# Altium schematic sheet Power Distribution - Steering, Pedal, Center Console, and Fan.SchDoc
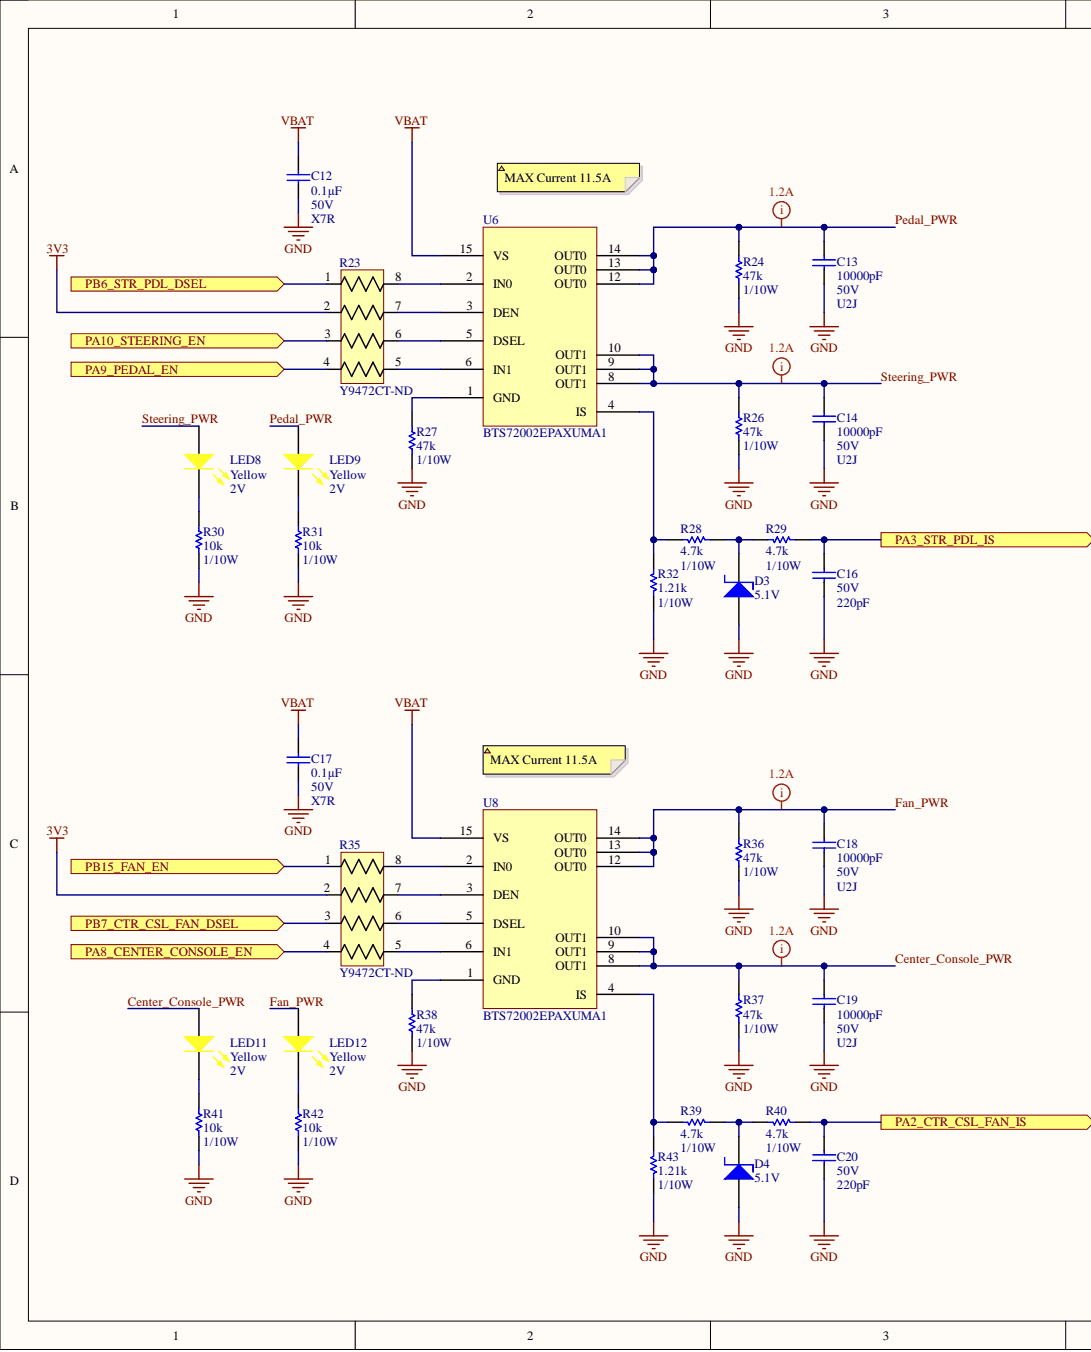
[diagram p0: Power Distribution - Steering, Pedal, Center Console, and Fan.SchDoc, left]
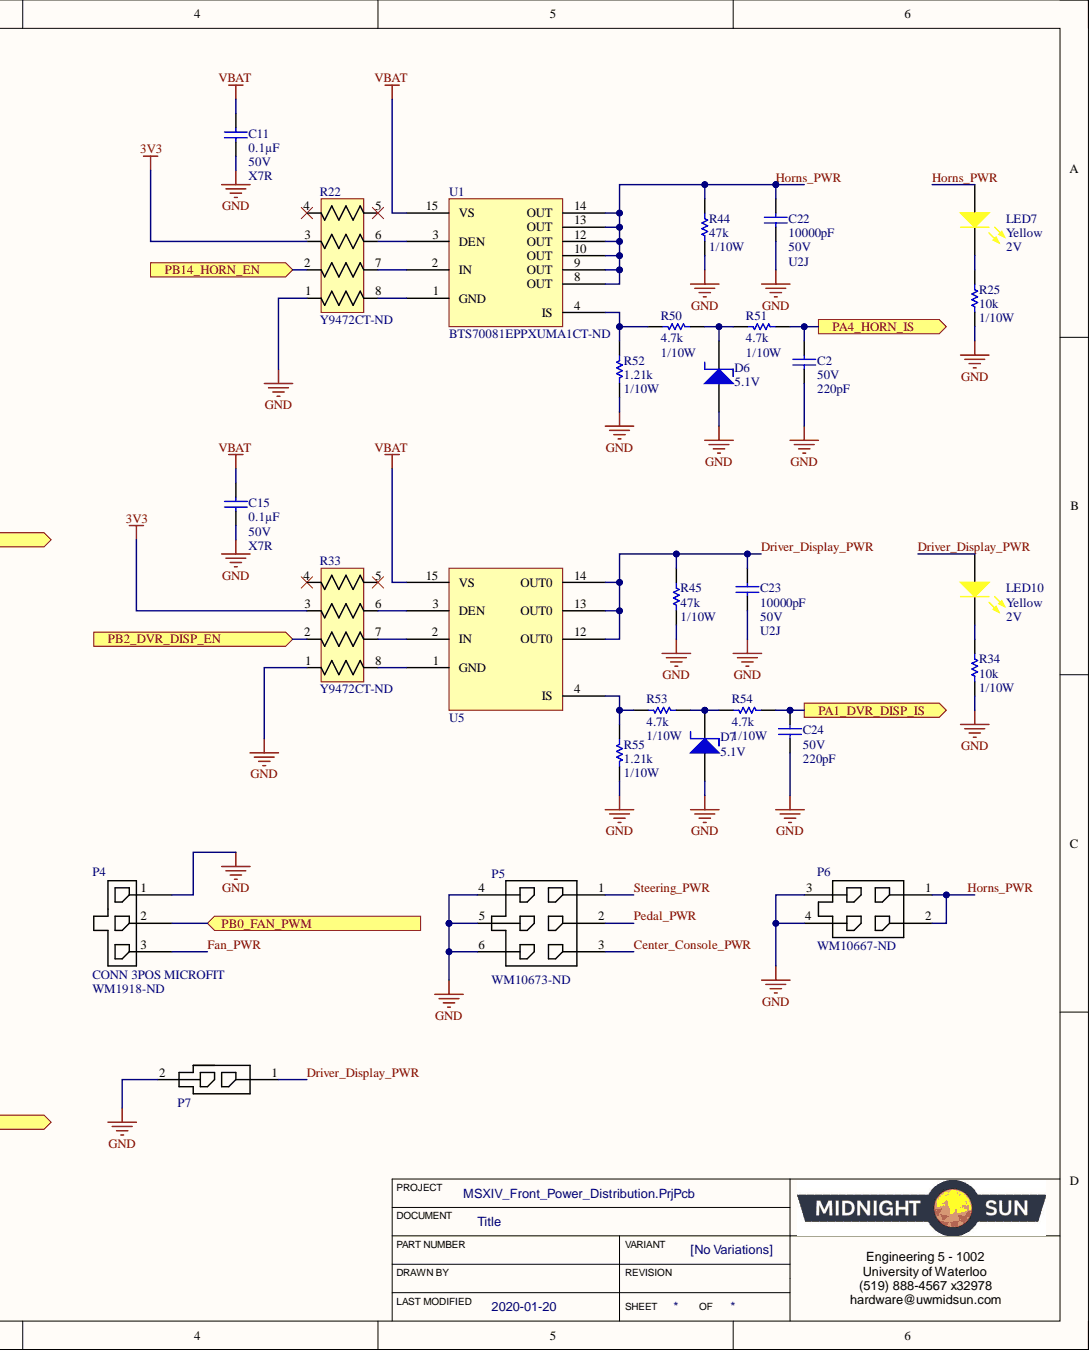
[diagram p1: Power Distribution - Steering, Pedal, Center Console, and Fan.SchDoc, right]

## Parts
C2  Capacitance: 220pF  Voltage Rating: 50V  [CAP, 0603]  (hidden comment: CAP CER 220PF 50V C0G/NP0 0603)
C11  Capacitance: 0.1µF  Temperature Coefficient: X7R  Voltage: 50V  [CAP, 0603]  (hidden comment: CAP CER 0.1UF 50V 10% X7R 0603)
C12  Capacitance: 0.1µF  Temperature Coefficient: X7R  Voltage: 50V  [CAP, 0603]  (hidden comment: CAP CER 0.1UF 50V 10% X7R 0603)
C13  Capacitance: 10000pF  Temperature Coefficient: U2J  Voltage: 50V  [CAP, 0603]  (hidden comment: CAP CER 10nF 50V 5% X7R 0603)
C14  Capacitance: 10000pF  Temperature Coefficient: U2J  Voltage: 50V  [CAP, 0603]  (hidden comment: CAP CER 10nF 50V 5% X7R 0603)
C15  Capacitance: 0.1µF  Temperature Coefficient: X7R  Voltage: 50V  [CAP, 0603]  (hidden comment: CAP CER 0.1UF 50V 10% X7R 0603)
C16  Capacitance: 220pF  Voltage Rating: 50V  [CAP, 0603]  (hidden comment: CAP CER 220PF 50V C0G/NP0 0603)
C17  Capacitance: 0.1µF  Temperature Coefficient: X7R  Voltage: 50V  [CAP, 0603]  (hidden comment: CAP CER 0.1UF 50V 10% X7R 0603)
C18  Capacitance: 10000pF  Temperature Coefficient: U2J  Voltage: 50V  [CAP, 0603]  (hidden comment: CAP CER 10nF 50V 5% X7R 0603)
C19  Capacitance: 10000pF  Temperature Coefficient: U2J  Voltage: 50V  [CAP, 0603]  (hidden comment: CAP CER 10nF 50V 5% X7R 0603)
C20  Capacitance: 220pF  Voltage Rating: 50V  [CAP, 0603]  (hidden comment: CAP CER 220PF 50V C0G/NP0 0603)
C22  Capacitance: 10000pF  Temperature Coefficient: U2J  Voltage: 50V  [CAP, 0603]  (hidden comment: CAP CER 10nF 50V 5% X7R 0603)
C23  Capacitance: 10000pF  Temperature Coefficient: U2J  Voltage: 50V  [CAP, 0603]  (hidden comment: CAP CER 10nF 50V 5% X7R 0603)
C24  Capacitance: 220pF  Voltage Rating: 50V  [CAP, 0603]  (hidden comment: CAP CER 220PF 50V C0G/NP0 0603)
D3  Voltage - Zener (Nom) (Vz): 5.1V  [DO-214AA Diode]  (hidden comment: DIODE ZENER 5.1V 500MW SOD123)
D4  Voltage - Zener (Nom) (Vz): 5.1V  [DO-214AA Diode]  (hidden comment: DIODE ZENER 5.1V 500MW SOD123)
D6  Voltage - Zener (Nom) (Vz): 5.1V  [DO-214AA Diode]  (hidden comment: DIODE ZENER 5.1V 500MW SOD123)
D7  Voltage - Zener (Nom) (Vz): 5.1V  [DO-214AA Diode]  (hidden comment: DIODE ZENER 5.1V 500MW SOD123)
LED7  Color: Yellow  Voltage - Forward (Vf) (Typ): 2V  [LED_0603]  (hidden comment: LED YELLOW CLEAR 2.1V 0603)
LED8  Color: Yellow  Voltage - Forward (Vf) (Typ): 2V  [LED_0603]  (hidden comment: LED YELLOW CLEAR 2.1V 0603)
LED9  Color: Yellow  Voltage - Forward (Vf) (Typ): 2V  [LED_0603]  (hidden comment: LED YELLOW CLEAR 2.1V 0603)
LED10  Color: Yellow  Voltage - Forward (Vf) (Typ): 2V  [LED_0603]  (hidden comment: LED YELLOW CLEAR 2.1V 0603)
LED11  Color: Yellow  Voltage - Forward (Vf) (Typ): 2V  [LED_0603]  (hidden comment: LED YELLOW CLEAR 2.1V 0603)
LED12  Color: Yellow  Voltage - Forward (Vf) (Typ): 2V  [LED_0603]  (hidden comment: LED YELLOW CLEAR 2.1V 0603)
P4  CONN 3POS MICROFIT  Supplier Part Number 1: WM1918-ND  [CONN, 3 POS MICROFIT]
P5  Supplier Part Number 1: WM10673-ND  [CONN, 6POS MICRO-FIT 3MM]  (hidden comment: CONN 6POS MICRO-FIT 3mm)
P6  Supplier Part Number 1: WM10667-ND  [CONN 4POS MICROFIT (2x2)]  (hidden comment: CONN 4POS MICRO-FIT 3mm)
P7  CONN 2POS MICRO-FIT 3mm  [CONN, 2POS MICRO-FIT]  (value not drawn)
R22  Supplier Part Number 1: Y9472CT-ND  [RES ARRAY 4RES 1206]  (hidden comment: RES ARRAY 4 RES 4.7K OHM 1206)
R23  Supplier Part Number 1: Y9472CT-ND  [RES ARRAY 4RES 1206]  (hidden comment: RES ARRAY 4 RES 4.7K OHM 1206)
R24  Power Rating: 1/10W  Resistance: 47k  [RES, 0603]  (hidden comment: RES 47K OHM 1% 1/10W 0603)
R25  Power: 1/10W  Resistance: 10k  [RES, 0603]  (hidden comment: RES 10K OHM 1% 1/10W 0603)
R26  Power Rating: 1/10W  Resistance: 47k  [RES, 0603]  (hidden comment: RES 47K OHM 1% 1/10W 0603)
R27  Power Rating: 1/10W  Resistance: 47k  [RES, 0603]  (hidden comment: RES 47K OHM 1% 1/10W 0603)
R28  Resistance (Ohms): 4.7k  [RES, 0603]  (hidden comment: RES 4.7K OHM 1% 1/10W 0603)
R29  Resistance (Ohms): 4.7k  [RES, 0603]  (hidden comment: RES 4.7K OHM 1% 1/10W 0603)
R30  Power: 1/10W  Resistance: 10k  [RES, 0603]  (hidden comment: RES 10K OHM 1% 1/10W 0603)
R31  Power: 1/10W  Resistance: 10k  [RES, 0603]  (hidden comment: RES 10K OHM 1% 1/10W 0603)
R32  Power: 1/10W  Resistance: 1.21k  [RES, 0603]  (hidden comment: RES 1.21K OHM 1% 1/10W 0603)
R33  Supplier Part Number 1: Y9472CT-ND  [RES ARRAY 4RES 1206]  (hidden comment: RES ARRAY 4 RES 4.7K OHM 1206)
R34  Power: 1/10W  Resistance: 10k  [RES, 0603]  (hidden comment: RES 10K OHM 1% 1/10W 0603)
R35  Supplier Part Number 1: Y9472CT-ND  [RES ARRAY 4RES 1206]  (hidden comment: RES ARRAY 4 RES 4.7K OHM 1206)
R36  Power Rating: 1/10W  Resistance: 47k  [RES, 0603]  (hidden comment: RES 47K OHM 1% 1/10W 0603)
R37  Power Rating: 1/10W  Resistance: 47k  [RES, 0603]  (hidden comment: RES 47K OHM 1% 1/10W 0603)
R38  Power Rating: 1/10W  Resistance: 47k  [RES, 0603]  (hidden comment: RES 47K OHM 1% 1/10W 0603)
R39  Resistance (Ohms): 4.7k  [RES, 0603]  (hidden comment: RES 4.7K OHM 1% 1/10W 0603)
R40  Resistance (Ohms): 4.7k  [RES, 0603]  (hidden comment: RES 4.7K OHM 1% 1/10W 0603)
R41  Power: 1/10W  Resistance: 10k  [RES, 0603]  (hidden comment: RES 10K OHM 1% 1/10W 0603)
R42  Power: 1/10W  Resistance: 10k  [RES, 0603]  (hidden comment: RES 10K OHM 1% 1/10W 0603)
R43  Power: 1/10W  Resistance: 1.21k  [RES, 0603]  (hidden comment: RES 1.21K OHM 1% 1/10W 0603)
R44  Power Rating: 1/10W  Resistance: 47k  [RES, 0603]  (hidden comment: RES 47K OHM 1% 1/10W 0603)
R45  Power Rating: 1/10W  Resistance: 47k  [RES, 0603]  (hidden comment: RES 47K OHM 1% 1/10W 0603)
R50  Resistance (Ohms): 4.7k  [RES, 0603]  (hidden comment: RES 4.7K OHM 1% 1/10W 0603)
R51  Resistance (Ohms): 4.7k  [RES, 0603]  (hidden comment: RES 4.7K OHM 1% 1/10W 0603)
R52  Power: 1/10W  Resistance: 1.21k  [RES, 0603]  (hidden comment: RES 1.21K OHM 1% 1/10W 0603)
R53  Resistance (Ohms): 4.7k  [RES, 0603]  (hidden comment: RES 4.7K OHM 1% 1/10W 0603)
R54  Resistance (Ohms): 4.7k  [RES, 0603]  (hidden comment: RES 4.7K OHM 1% 1/10W 0603)
R55  Power: 1/10W  Resistance: 1.21k  [RES, 0603]  (hidden comment: RES 1.21K OHM 1% 1/10W 0603)
U1  Manufacturer Part Number: BTS70081EPPXUMA1CT-ND  [IC LOAD SWITCH PG-TSDSO-14-22]  (hidden comment: IC LOAD SWITCH BTS70081EPPXUMA1)
U5  IC LOAD SWITCH BTS70401EPA PG-TSDSO-14-22  [IC LOAD SWITCH PG-TSDSO-14-22]  (value not drawn)
U6  Manufacturer Part Number: BTS72002EPAXUMA1  [IC LOAD SWITCH PG-TSDSO-14-22]  (hidden comment: IC LOAD SWITCH BTS7200-2EPA PG-TSDSO-14_1)
U8  Manufacturer Part Number: BTS72002EPAXUMA1  [IC LOAD SWITCH PG-TSDSO-14-22]  (hidden comment: IC LOAD SWITCH BTS7200-2EPA PG-TSDSO-14_1)

## Ports
PA1_DVR_DISP_IS, PA2_CTR_CSL_FAN_IS, PA3_STR_PDL_IS, PA4_HORN_IS, PA8_CENTER_CONSOLE_EN, PA9_PEDAL_EN, PA10_STEERING_EN, PB0_FAN_PWM, PB2_DVR_DISP_EN, PB6_STR_PDL_DSEL, PB7_CTR_CSL_FAN_DSEL, PB14_HORN_EN, PB15_FAN_EN

## Nets
3V3: R22.3 R23.2 R33.3 R35.2
GND: C2.2 C11.2 C12.2 C13.2 C14.2 C15.2 C16.2 C17.2 C18.2 C19.2 C20.2 C22.2 C23.2 C24.2 D3.1 D4.1 D6.1 D7.1 P4.1 P5.4 P5.5 P5.6 P6.3 P6.4 P7.2 R22.1 R24.2 R25.2 R26.2 R27.2 R30.2 R31.2 R32.2 R33.1 R34.2 R36.2 R37.2 R38.2 R41.2 R42.2 R43.2 R44.2 R45.2 R52.2 R55.2
VBAT: C11.1 C12.1 C15.1 C17.1 U1.15(VS) U5.15(VS) U6.15(VS) U8.15(VS)
Center_Console_PWR: C19.1 LED11.1 P5.3 R37.1 U8.8(OUT1) U8.9(OUT1) U8.10(OUT1)
Driver_Display_PWR: C23.1 LED10.1 P7.1 R45.1 U5.12(OUT0) U5.13(OUT0) U5.14(OUT0)
Fan_PWR: C18.1 LED12.1 P4.3 R36.1 U8.12(OUT0) U8.13(OUT0) U8.14(OUT0)
Horns_PWR: C22.1 LED7.1 P6.1 P6.2 R44.1 U1.8(OUT) U1.9(OUT) U1.10(OUT) U1.12(OUT) U1.13(OUT) U1.14(OUT)
PA1_DVR_DISP_IS: C24.1 R54.1 ; port PA1_DVR_DISP_IS
PA2_CTR_CSL_FAN_IS: C20.1 R40.1 ; port PA2_CTR_CSL_FAN_IS
PA3_STR_PDL_IS: C16.1 R29.1 ; port PA3_STR_PDL_IS
PA4_HORN_IS: C2.1 R51.1 ; port PA4_HORN_IS
PA8_CENTER_CONSOLE_EN: R35.4 ; port PA8_CENTER_CONSOLE_EN
PA9_PEDAL_EN: R23.4 ; port PA9_PEDAL_EN
PA10_STEERING_EN: R23.3 ; port PA10_STEERING_EN
PB0_FAN_PWM: P4.2 ; port PB0_FAN_PWM
PB2_DVR_DISP_EN: R33.2 ; port PB2_DVR_DISP_EN
PB6_STR_PDL_DSEL: R23.1 ; port PB6_STR_PDL_DSEL
PB7_CTR_CSL_FAN_DSEL: R35.3 ; port PB7_CTR_CSL_FAN_DSEL
PB14_HORN_EN: R22.2 ; port PB14_HORN_EN
PB15_FAN_EN: R35.1 ; port PB15_FAN_EN
Pedal_PWR: C13.1 LED9.1 P5.2 R24.1 U6.12(OUT0) U6.13(OUT0) U6.14(OUT0)
Steering_PWR: C14.1 LED8.1 P5.1 R26.1 U6.8(OUT1) U6.9(OUT1) U6.10(OUT1)
NetD3_2: D3.2 R28.1 R29.2
NetD4_2: D4.2 R39.1 R40.2
NetD6_2: D6.2 R50.1 R51.2
NetD7_2: D7.2 R53.1 R54.2
NetLED7_2: LED7.2 R25.1
NetLED8_2: LED8.2 R30.1
NetLED9_2: LED9.2 R31.1
NetLED10_2: LED10.2 R34.1
NetLED11_2: LED11.2 R41.1
NetLED12_2: LED12.2 R42.1
NetR22_6: R22.6 U1.3(DEN)
NetR22_7: R22.7 U1.2(IN)
NetR22_8: R22.8 U1.1(GND)
NetR23_5: R23.5 U6.6(IN1)
NetR23_6: R23.6 U6.5(DSEL)
NetR23_7: R23.7 U6.3(DEN)
NetR23_8: R23.8 U6.2(IN0)
NetR27_1: R27.1 U6.1(GND)
NetR28_2: R28.2 R32.1 U6.4(IS)
NetR33_6: R33.6 U5.3(DEN)
NetR33_7: R33.7 U5.2(IN)
NetR33_8: R33.8 U5.1(GND)
NetR35_5: R35.5 U8.6(IN1)
NetR35_6: R35.6 U8.5(DSEL)
NetR35_7: R35.7 U8.3(DEN)
NetR35_8: R35.8 U8.2(IN0)
NetR38_1: R38.1 U8.1(GND)
NetR39_2: R39.2 R43.1 U8.4(IS)
NetR50_2: R50.2 R52.1 U1.4(IS)
NetR53_2: R53.2 R55.1 U5.4(IS)

Not connected: R22 4 5; R33 4 5

## Library data of the parts
C2 C16 C20 C24 = CAP CER 220PF 50V C0G/NP0 0603: 220pF ±5% 50V Ceramic Capacitor C0G, NP0 0603 (1608 Metric); Manufacturer: KEMET; Manufacturer Part Number: C0603C221J5GACAUTO; Dissipation Factor: 0.1%; Case Code (Imperial): 0603; Case Code (Metric): 1608; Case/Package: 0603; Component Kind: Standard; ComponentLink1Description: https://datasheet.ciiva.com/18192/getdatasheetpartid-416741-18192869.pdf?src-supplier=Verical; ComponentLink1URL: https://datasheet.ciiva.com/18192/getdatasheetpartid-416741-18192869.pdf?src-supplier=Verical; ComponentLink2Description: https://datasheet.ciiva.com/22694/1633156-22694015.pdf?src-supplier=Element14; ComponentLink2URL: https://datasheet.ciiva.com/22694/1633156-22694015.pdf?src-supplier=Element14; Contact Plating: Tin; Depth: 0.8mm; Dielectric: C0G; Dielectric Material: Ceramic; Height: 0.8mm; Lead Free: Lead Free; Length: 1.6mm; Max Operating Temperature: 125°C; Min Operating Temperature: -55°C; Mount: Surface Mount; Package Quantity: 1; Packaging: Tape and Reel; Pricing 1: 1=0.14000, 10=0.09700, 100=0.04550, 500=0.03188, 1000=0.02640 (USD); Radiation Hardening: No; Ratings: AEC-Q200; REACH SVHC: No SVHC; RoHS: Yes; Stock 1: 35170; Supplier 1: Digi-Key; Supplier Part Number 1: 399-6868-1-ND; Termination: SMD/SMT; Thickness: 0.034inch; Tolerance: 5%; Voltage Rating (DC): 50V; Width: 0.031inch; Library Name: Schematic Diagrams.SchLib
C11 C12 C15 C17 = CAP CER 0.1UF 50V 10% X7R 0603: 0.10µF ±10% 50V Ceramic Capacitor X7R 0603 (1608 Metric); Manufacturer 1: AVX Corporation; Manufacturer Part Number 1: 06035C104KAT2A; Component Kind: Standard; ComponentLink1Description: http://datasheets.avx.com/X7RDielectric.pdf; ComponentLink1URL: http://datasheets.avx.com/X7RDielectric.pdf; Height - Seated (Max): -; Lead Spacing: -; Lead Style: -; Mounting Type: Surface Mount, MLCC; Operating Temperature: -55°C ~ 125°C; Package / Case: 0603 (1608 Metric); Packaging: Cut Tape (CT); Part Status: Active; Ratings: -; Size / Dimension: 0.063" L x 0.032" W (1.60mm x 0.81mm); Supplier 1: Digi-Key; Supplier Part Number 1: 478-5052-1-ND; Thickness (Max): 0.035" (0.90mm); Tolerance: ±10%; Library Name: Schematic Diagrams.SchLib
C13 C14 C18 C19 C22 C23 = CAP CER 10nF 50V 5% X7R 0603: 10000pF ±5% 50V Ceramic Capacitor U2J 0603 (1608 Metric); Manufacturer 1: KEMET; Manufacturer Part Number 1: C0603C103J5JAC7867; Component Kind: Standard; ComponentLink1Description: http://www.kemet.com/docfinder?Partnumber=C0603C103J5JAC7867; ComponentLink1URL: http://www.kemet.com/docfinder?Partnumber=C0603C103J5JAC7867; Failure Rate: -; Height - Seated (Max): -; Lead Spacing: -; Lead Style: -; Mounting Type: Surface Mount, MLCC; Operating Temperature: -55°C ~ 125°C; Package / Case: 0603 (1608 Metric); Packaging: Cut Tape (CT); Part Status: Active; Ratings: -; Size / Dimension: 0.063" L x 0.032" W (1.60mm x 0.80mm); Supplier 1: Digi-Key; Supplier Part Number 1: 399-13384-1-ND; Thickness (Max): 0.034" (0.87mm); Tolerance: ±5%; Library Name: Schematic Diagrams.SchLib
LED7-LED12 = LED YELLOW CLEAR 2.1V 0603: Yellow 590nm LED Indication - Discrete 2V 0603 (1608 Metric); Manufacturer: Wurth Electronics Inc.; Manufacturer Part Number: 150060YS75000; Current - Test: 20mA; Lens Color: Colorless; Lens Style/Size: Rectangle with Flat Top, 1.10mm x 0.70mm; Millicandela Rating: 120mcd; Viewing Angle: 140°; Wavelength - Peak: 595nm; Category: Optoelectronics; Component Kind: Standard; ComponentLink1Description: http://katalog.we-online.de/led/datasheet/150060YS75000.pdf; ComponentLink1URL: http://katalog.we-online.de/led/datasheet/150060YS75000.pdf; Features: -; Height (Max): 0.80mm; Lens Transparency: Clear; Mounting Type: Surface Mount; Package / Case: 0603 (1608 Metric); Packaging: Cut Tape (CT); Part Status: Active; Pricing 1: 1=0.21, 100=0.1617, 500=0.13964 (CAD); RoHS: RoHS Compliant; Size / Dimension: 1.60mm L x 0.80mm W; Stock 1: 10523; Supplier 1: Digi-Key; Supplier Device Package: 0603; Supplier Part Number 1: 732-4981-1-ND; Wavelength - Dominant: 590nm; Library Name: Schematic Diagrams.SchLib
P4 = CONN 3POS MICROFIT: Connector Header Through Hole 3 position 0.118" (3.00mm); Contact Length: 0.125" (3.18mm); Mated Stacking Heights: 17.56mm; Material Flammability Rating: UL94 V-0; Number of Positions: 3; Number of Positions Loaded: All; Shrouding Shrouded: 4 Wall; Style: Board to Cable/Wire; Component Kind: Standard; Connector Type: Header; Contact Finish: Tin; Contact Finish Thickness: 100.0µin (2.54µm); Contact Material: Brass; Contact Shape: Square; Contact Type: Male Pin; Fastening Type: Locking Ramp; Features: Board Guide; Insulation Color: Black; Insulation Height: 0.390" (9.91mm); Insulation Material: Liquid Crystal Polymer (LCP), Glass Filled; Mounting Type: Through Hole; Number of Rows: 1; Packaging: Tray; Part Status: Active; Supplier 1: Digi-Key; Termination Kinked Pin: Solder; Library Name: Schematic Diagrams.SchLib
P5 = CONN 6POS MICRO-FIT 3mm: Connector Header Through Hole 6 position 0.118" (3.00mm); Component Kind: Standard; ComponentLink1Description: http://www.literature.molex.com/SQLImages/kelmscott/Molex/PDF_Images/987650-5984.pdf; ComponentLink1URL: http://www.literature.molex.com/SQLImages/kelmscott/Molex/PDF_Images/987650-5984.pdf; ComponentLink2Description: https://www.molex.com/pdm_docs/ps/PS-43045.pdf; ComponentLink2URL: https://www.molex.com/pdm_docs/ps/PS-43045.pdf; ComponentLink3Description: https://www.molex.com/pdm_docs/sd/430450627_sd.pdf; ComponentLink3URL: https://www.molex.com/pdm_docs/sd/430450627_sd.pdf; Supplier 1: Digi-Key; Library Name: Schematic Diagrams.SchLib
P6 = CONN 4POS MICRO-FIT 3mm: Micro-Fit 3.0 Vertical Header, 3.00mm Pitch, Dual Row, 4 Circuits, with PCB Polarizing Peg, Tin, Glow-Wire Capable, Black; Manufacturer: Molex, LLC; Manufacturer Part Number: 430450427; Contact Length - Post: 0.125" (3.18mm); Contact Resistance: 10mR; Material Flammability Rating: UL94 V-0; Number of Contacts: 4; Number of Ports: 1; Number of Positions: 6; Number of Positions Loaded: All; Pitch - Mating: 0.118" (3.00mm); Row Spacing: 0.118inch; Shrouding: Shrouded - 4 Wall; Style: Board to Cable/Wire; Applications: General Purpose, Medical, Military, Telecommunications; Category: Connectors, Interconnects; Color: Black; Component Kind: Standard; ComponentLink4Description: http://www.molex.com/webdocs/datasheets/pdf/en-us/0430450427_PCB_HEADERS.pdf; ComponentLink4URL: http://www.molex.com/webdocs/datasheets/pdf/en-us/0430450427_PCB_HEADERS.pdf; Connector Type: Header; Contact Finish - Mating: Tin; Contact Finish - Post: Tin; Contact Finish Thickness - Mating: 60.0µin (1.52µm); Contact Finish Thickness - Post: -; Contact Length - Mating: -; Contact Material: Brass; Contact Plating: Tin; Contact Shape: Square; Contact Type: Male Pin; Current Rating: -; Depth: 8.77mm; Fastening Type: Locking Ramp; Features: Board Guide; Housing Color: Black; Housing Material: Polymer; Ingress Protection: -; Insulation Color: Black; Insulation Height: 0.390" (9.91mm); Insulation Material: Liquid Crystal Polymer (LCP), Glass Filled; Length: 10.15mm; Mated Stacking Heights: -; Max Operating Temperature: 105°C; Min Operating Temperature: -40°C; Mount: Through Hole; Mounting Type: Through Hole; Number of Rows: 2; Number of Terminals: 4; Operating Temperature: -; Orientation: Straight; Overall Contact Length: -; Package Quantity: 2232; Packaging: Tray; Part Status: Active; Pitch: 3mm; RoHS: RoHS Compliant; Row Spacing - Mating: 0.118" (3.00mm); Supplier 1: Digi-Key; Termination: Solder; Voltage Rating: -; Library Name: Schematic Diagrams.SchLib
P7 = CONN 2POS MICRO-FIT 3mm: Connector Header Through Hole 2 position; Manufacturer: Molex; Manufacturer Part Number: 43045-0227; Contact Resistance: 10mR; Current Rating: 5A; Gender: Male; Glow Wire Compliant: Compliant; Max Voltage Rating (DC): 250.25kV; Number of Circuits: 2; Number of Ports: 1; Voltage Rating: 600V; Component Kind: Standard; ComponentLink1Description: http://www.onlinecomponents.com/productFiles/mf-MOL/307170430450227_pcb_headers.pdf; ComponentLink1URL: http://www.onlinecomponents.com/productFiles/mf-MOL/307170430450227_pcb_headers.pdf; ComponentLink2Description: http://www.mouser.com/ds/2/276/0430450227_PCB_HEADERS-142611.pdf; ComponentLink2URL: http://www.mouser.com/ds/2/276/0430450227_PCB_HEADERS-142611.pdf; ComponentLink3Description: http://uk.rs-online.com/webdocs/13c3/0900766b813c3a46.pdf; ComponentLink3URL: http://uk.rs-online.com/webdocs/13c3/0900766b813c3a46.pdf; ComponentLink4Description: http://www.molex.com/webdocs/datasheets/pdf/en-us/0430450227_PCB_HEADERS.pdf; ComponentLink4URL: http://www.molex.com/webdocs/datasheets/pdf/en-us/0430450227_PCB_HEADERS.pdf; Contact Material: Brass; Contact Plating: Tin; Depth: 8.77mm; Halogen Free: Low Halogen; Housing Colour: Black; Housing Material: Thermoplastic; Length: 7.15mm; Max Operating Temperature: 105°C; Min Operating Temperature: -40°C; Mount: Through Hole; Number of Contacts: 2; Number of Positions: 2; Number of Rows: 2; Number of Terminals: 2; Orientation: Vertical; Package Quantity: 3168; Packaging: Tray; Pitch: 3mm; Pricing 1: 1=0.84, 10=0.74, 25=0.6968, 50=0.6676, 100=0.6387, 250=0.5806, 500=0.52254, 1000=0.46448, 2500=0.42094 (USD); Radiation Hardening: No; Row Spacing: 3mm; Stock 1: 3657; Supplier 1: Digi-Key; Supplier Part Number 1: WM10657-ND; Termination: Solder; Library Name: Schematic Diagrams.SchLib
R22 R23 R33 R35 = RES ARRAY 4 RES 4.7K OHM 1206: 4.7k Ohm ±5% 62.5mW Power Per Element Isolated 4 Resistor Network/Array ±200ppm/°C 1206 (3216 Metric), Convex, Long Side Terminals; Applications Automotive: AEC-Q200; Number of Resistors: 4; Applications: -; Category: Resistors; Circuit Type: Isolated; Component Kind: Standard; ComponentLink1Description: https://industrial.panasonic.com/cdbs/www-data/pdf/AOC0000/AOC0000C14.pdf; ComponentLink1URL: https://industrial.panasonic.com/cdbs/www-data/pdf/AOC0000/AOC0000C14.pdf; Height - Seated (Max): 0.024" (0.60mm); Mounting Type: Surface Mount; Number of Pins: 8; Operating Temperature: -55°C ~ 125°C; Package / Case: 1206 (3216 Metric), Convex, Long Side Terminals; Packaging: Cut Tape (CT); Part Status: Active; Power Per Element: 62.5mW; Resistance (Ohms): 4.7k; Resistor Matching Ratio: -; Resistor-Ratio-Drift: -; Series: EXB; Size / Dimension: 0.126" L x 0.063" W (3.20mm x 1.60mm); Supplier 1: Digi-Key; Supplier Device Package: 1206; Temperature Coefficient: ±200ppm/°C; Tolerance: ±5%; Library Name: Schematic Diagrams.SchLib
R24 R26 R27 R36-R38 R44 R45 = RES 47K OHM 1% 1/10W 0603: 47 kOhms ±1% 0.1W, 1/10W Chip Resistor 0603 (1608 Metric) Automotive AEC-Q200 Thick Film; Manufacturer: Panasonic; Manufacturer Part Number: ERJ3EKF4702V; Max Power Dissipation: 100mW; Temperature Coefficient: 100ppm/°C; Voltage Rating: 75V; Working Voltage: 6V; Case Code (Imperial): 0603; Case Code (Metric): 1608; Case/Package: 0603; Component Kind: Standard; ComponentLink1Description: https://industrial.panasonic.com/cdbs/www-data/pdf/RDO0000/AOA0000C291.pdf; ComponentLink1URL: https://industrial.panasonic.com/cdbs/www-data/pdf/RDO0000/AOA0000C291.pdf; ComponentLink2Description: https://datasheet.ciiva.com/6138/aoa0000ce2-6138149.pdf?src-supplier=Farnell; ComponentLink2URL: https://datasheet.ciiva.com/6138/aoa0000ce2-6138149.pdf?src-supplier=Farnell; ComponentLink3Description: https://datasheet.ciiva.com/7802/aoa0000ce2-7802421.pdf?src-supplier=Element14; ComponentLink3URL: https://datasheet.ciiva.com/7802/aoa0000ce2-7802421.pdf?src-supplier=Element14; ComponentLink4Description: https://datasheet.ciiva.com/48531/aoa0000ce2-48531029.pdf?src-supplier=Avnet; ComponentLink4URL: https://datasheet.ciiva.com/48531/aoa0000ce2-48531029.pdf?src-supplier=Avnet; ComponentLink5Description: https://datasheet.ciiva.com/16514/aoa0000ce2-16514071.pdf?src-supplier=Avnet+Asia; ComponentLink5URL: https://datasheet.ciiva.com/16514/aoa0000ce2-16514071.pdf?src-supplier=Avnet+Asia; ComponentLink6Description: https://datasheet.ciiva.com/46398/1678782-46398088.pdf?src-supplier=Element14; ComponentLink6URL: https://datasheet.ciiva.com/46398/1678782-46398088.pdf?src-supplier=Element14; ComponentLink7Description: https://datasheet.ciiva.com/24069/aoa0000ce2-24069209.pdf?src-supplier=Mouser; ComponentLink7URL: https://datasheet.ciiva.com/24069/aoa0000ce2-24069209.pdf?src-supplier=Mouser; ComponentLink8Description: https://datasheet.ciiva.com/46398/1678782-46398089.pdf?src-supplier=Element14; ComponentLink8URL: https://datasheet.ciiva.com/46398/1678782-46398089.pdf?src-supplier=Element14; ComponentLink9Description: https://datasheet.ciiva.com/30236/aoa0000ce2-30236698.pdf?src-supplier=Onlinecomponents.com; ComponentLink9URL: https://datasheet.ciiva.com/30236/aoa0000ce2-30236698.pdf?src-supplier=Onlinecomponents.com; ComponentLink10Description: https://datasheet.ciiva.com/22722/426256-22722781.pdf?src-supplier=Element14; ComponentLink10URL: https://datasheet.ciiva.com/22722/426256-22722781.pdf?src-supplier=Element14; ComponentLink11Description: https://datasheet.ciiva.com/16758/70260748-16758831.pdf?src-supplier=Allied+Electronics; ComponentLink11URL: https://datasheet.ciiva.com/16758/70260748-16758831.pdf?src-supplier=Allied+Electronics; Composition: Thick Film; Contact Plating: Tin; Depth: 0.8mm; Features: Automotive AEC-Q200; Height: 0.022inch; Lead Free: Lead Free; Length: 1.6mm; Max Operating Temperature: 155°C; Military Standard: Not; Min Operating Temperature: -55°C; Mount: Surface Mount; Number of Pins: 2; Number of Terminations: 2; Package Quantity: 1; Packaging: Tape and Reel; Pricing 1: 1 = 0.100000, 10 = 0.065000, 100 = 0.026400, 1000 = 0.011860, 2500 = 0.010290 (USD); Radiation Hardening: No; REACH SVHC: No SVHC; RoHS: Yes; Stock 1: 1704740; Supplier 1: Digi-Key; Supplier Part Number 1: P47.0KHCT-ND; Termination: SMD/SMT; Tolerance: 1%; Voltage Rating (DC): 75V; Width: 0.031inch; Library Name: Schematic Diagrams.SchLib
R25 R30 R31 R34 R41 R42 = RES 10K OHM 1% 1/10W 0603: RES SMD 10K OHM 1% 1/10W 0603; Manufacturer 1: Yageo; Manufacturer Part Number 1: RC0603FR-0710KL; Temperature Coefficient: ±100ppm/°C; Component Kind: Standard; ComponentLink1Description: http://www.yageo.com/documents/recent/PYu-RC_Group_51_RoHS_L_7.pdf; ComponentLink1URL: http://www.yageo.com/documents/recent/PYu-RC_Group_51_RoHS_L_7.pdf; Composition: Thick Film; Failure Rate: -; Height - Seated (Max): 0.022" (0.55mm); Operating Temperature: -55°C ~ 155°C; Package / Case: 0603 (1608 Metric); Packaging: Cut Tape (CT); Part Status: Active; Size / Dimension: 0.063" L x 0.031" W (1.60mm x 0.80mm); Supplier 1: Digi-Key; Supplier Device Package: 0603; Supplier Part Number 1: 311-10.0KHRCT-ND; Tolerance: ±1%; Library Name: Schematic Diagrams.SchLib
R28 R29 R39 R40 R50 R51 R53 R54 = RES 4.7K OHM 1% 1/10W 0603: 4.7k Ohm ±1% 0.1W, 1/10W Chip Resistor 0603 (1608 Metric) Moisture Resistant Thick Film; Manufacturer 1: Yageo; Manufacturer Part Number 1: RC0603FR-074K7L; Description 1: RES SMD 4.7K OHM 1% 1/10W 0603; Temperature Coefficient: ±100ppm/°C; Category: Resistors; Component Kind: Standard; ComponentLink1Description: http://www.yageo.com/documents/recent/PYu-RC_Group_51_RoHS_L_7.pdf; ComponentLink1URL: http://www.yageo.com/documents/recent/PYu-RC_Group_51_RoHS_L_7.pdf; Composition: Thick Film; Failure Rate: -; Features: Moisture Resistant; Height - Seated (Max): 0.022" (0.55mm); Operating Temperature: -55°C ~ 155°C; Package / Case: 0603 (1608 Metric); Packaging: Cut Tape (CT); Part Status: Active; Power (Watts): 1/10W; Pricing 1: 1=0.16, 10=0.022, 100=0.0089, 1000=0.00402, 2500=0.00349 (CAD); RoHS: RoHS Compliant; Size / Dimension: 0.063" L x 0.031" W (1.60mm x 0.80mm); Stock 1: 3487566; Supplier 1: Digi-Key; Supplier Device Package: 0603; Supplier Part Number 1: 311-4.70KHRCT-ND; Tolerance: ±1%; Library Name: Schematic Diagrams.SchLib
R32 R43 R52 R55 = RES 1.21K OHM 1% 1/10W 0603: 1.21k Ohm ±1% 0.1W, 1/10W Chip Resistor 0603 (1608 Metric) Moisture Resistant Thick Film; Manufacturer 1: Yageo; Manufacturer Part Number 1: RC0603FR-071K21L; Temperature Coefficient: ±100ppm/°C; Component Kind: Standard; ComponentLink1Description: http://www.yageo.com/documents/recent/PYu-RC_Group_51_RoHS_L_7.pdf; ComponentLink1URL: http://www.yageo.com/documents/recent/PYu-RC_Group_51_RoHS_L_7.pdf; Composition: Thick Film; Failure Rate: -; Height - Seated (Max): 0.022" (0.55mm); Operating Temperature: -55°C ~ 155°C; Package / Case: 0603 (1608 Metric); Packaging: Cut Tape (CT); Part Status: Active; Size / Dimension: 0.063" L x 0.031" W (1.60mm x 0.80mm); Supplier 1: Digi-Key; Supplier Device Package: 0603; Supplier Part Number 1: 311-1.21KHRCT-ND; Tolerance: ±1%; Library Name: Schematic Diagrams.SchLib
U1 = IC LOAD SWITCH BTS70081EPPXUMA1: Power Switch/Driver 1:1 N-Channel 11A PG-TSDSO-14-22; Manufacturer: Infineon; Component Kind: Standard; ComponentLink1Description: https://media.digikey.com/pdf/Data%20Sheets/Infineon%20PDFs/BTS7008-1EPP_v1.03_4-29-19.pdf; ComponentLink1URL: https://media.digikey.com/pdf/Data%20Sheets/Infineon%20PDFs/BTS7008-1EPP_v1.03_4-29-19.pdf; RoHS: YES; Supplier 1: Digi-Key; Supplier Part Number 1: BTS70081EPPXUMA1CT-ND; Library Name: Schematic Diagrams.SchLib
U5 = IC LOAD SWITCH BTS70401EPA PG-TSDSO-14-22: Power Switch/Driver 1:1 N-Channel 4.5A PG-TSDSO-14-22; Manufacturer: Infineon; Manufacturer Part Number: BTS70401EPAXUMA1; Component Kind: Standard; ComponentLink1Description: https://www.infineon.com/dgdl/Infineon-BTS7040-1EPA-DS-v01_00-EN.pdf?fileId=5546d462636cc8fb0163fe8f965308bc; ComponentLink1URL: https://www.infineon.com/dgdl/Infineon-BTS7040-1EPA-DS-v01_00-EN.pdf?fileId=5546d462636cc8fb0163fe8f965308bc; Supplier 1: Digi-Key; Supplier Part Number 1: BTS70401EPAXUMA1CT-ND; Library Name: Schematic Diagrams.SchLib
U6 U8 = IC LOAD SWITCH BTS7200-2EPA PG-TSDSO-14_1: Power Switch/Driver 1:1 N-Channel 1.2A PG-TSDSO-14-22; Manufacturer: Infineon; Component Kind: Standard; ComponentLink1Description: https://www.infineon.com/dgdl/Infineon-BTS7200-2EPA-DS-v01_00-EN.pdf?fileId=5546d462636cc8fb016421e548a61215; ComponentLink1URL: https://www.infineon.com/dgdl/Infineon-BTS7200-2EPA-DS-v01_00-EN.pdf?fileId=5546d462636cc8fb016421e548a61215; Pricing 1: 1=1.31000, 10=1.17500, 100=0.91640, 500=0.75700, 1000=0.59763 (USD); RoHS: No; Stock 1: 1973; Supplier 1: Digi-Key; Supplier Part Number 1: BTS72002EPAXUMA1CT-ND; Library Name: Schematic Diagrams.SchLib
D3 D4 D6 D7 = DIODE ZENER 5.1V 500MW SOD123: Component Kind: Standard; Supplier 1: Digi-Key; Supplier Part Number 1: MMSZ5231BT1GOSCT-ND; Library Name: Schematic Diagrams.SchLib
